# Altium schematic sheet USB-C Power.SchDoc("USB-C Power")
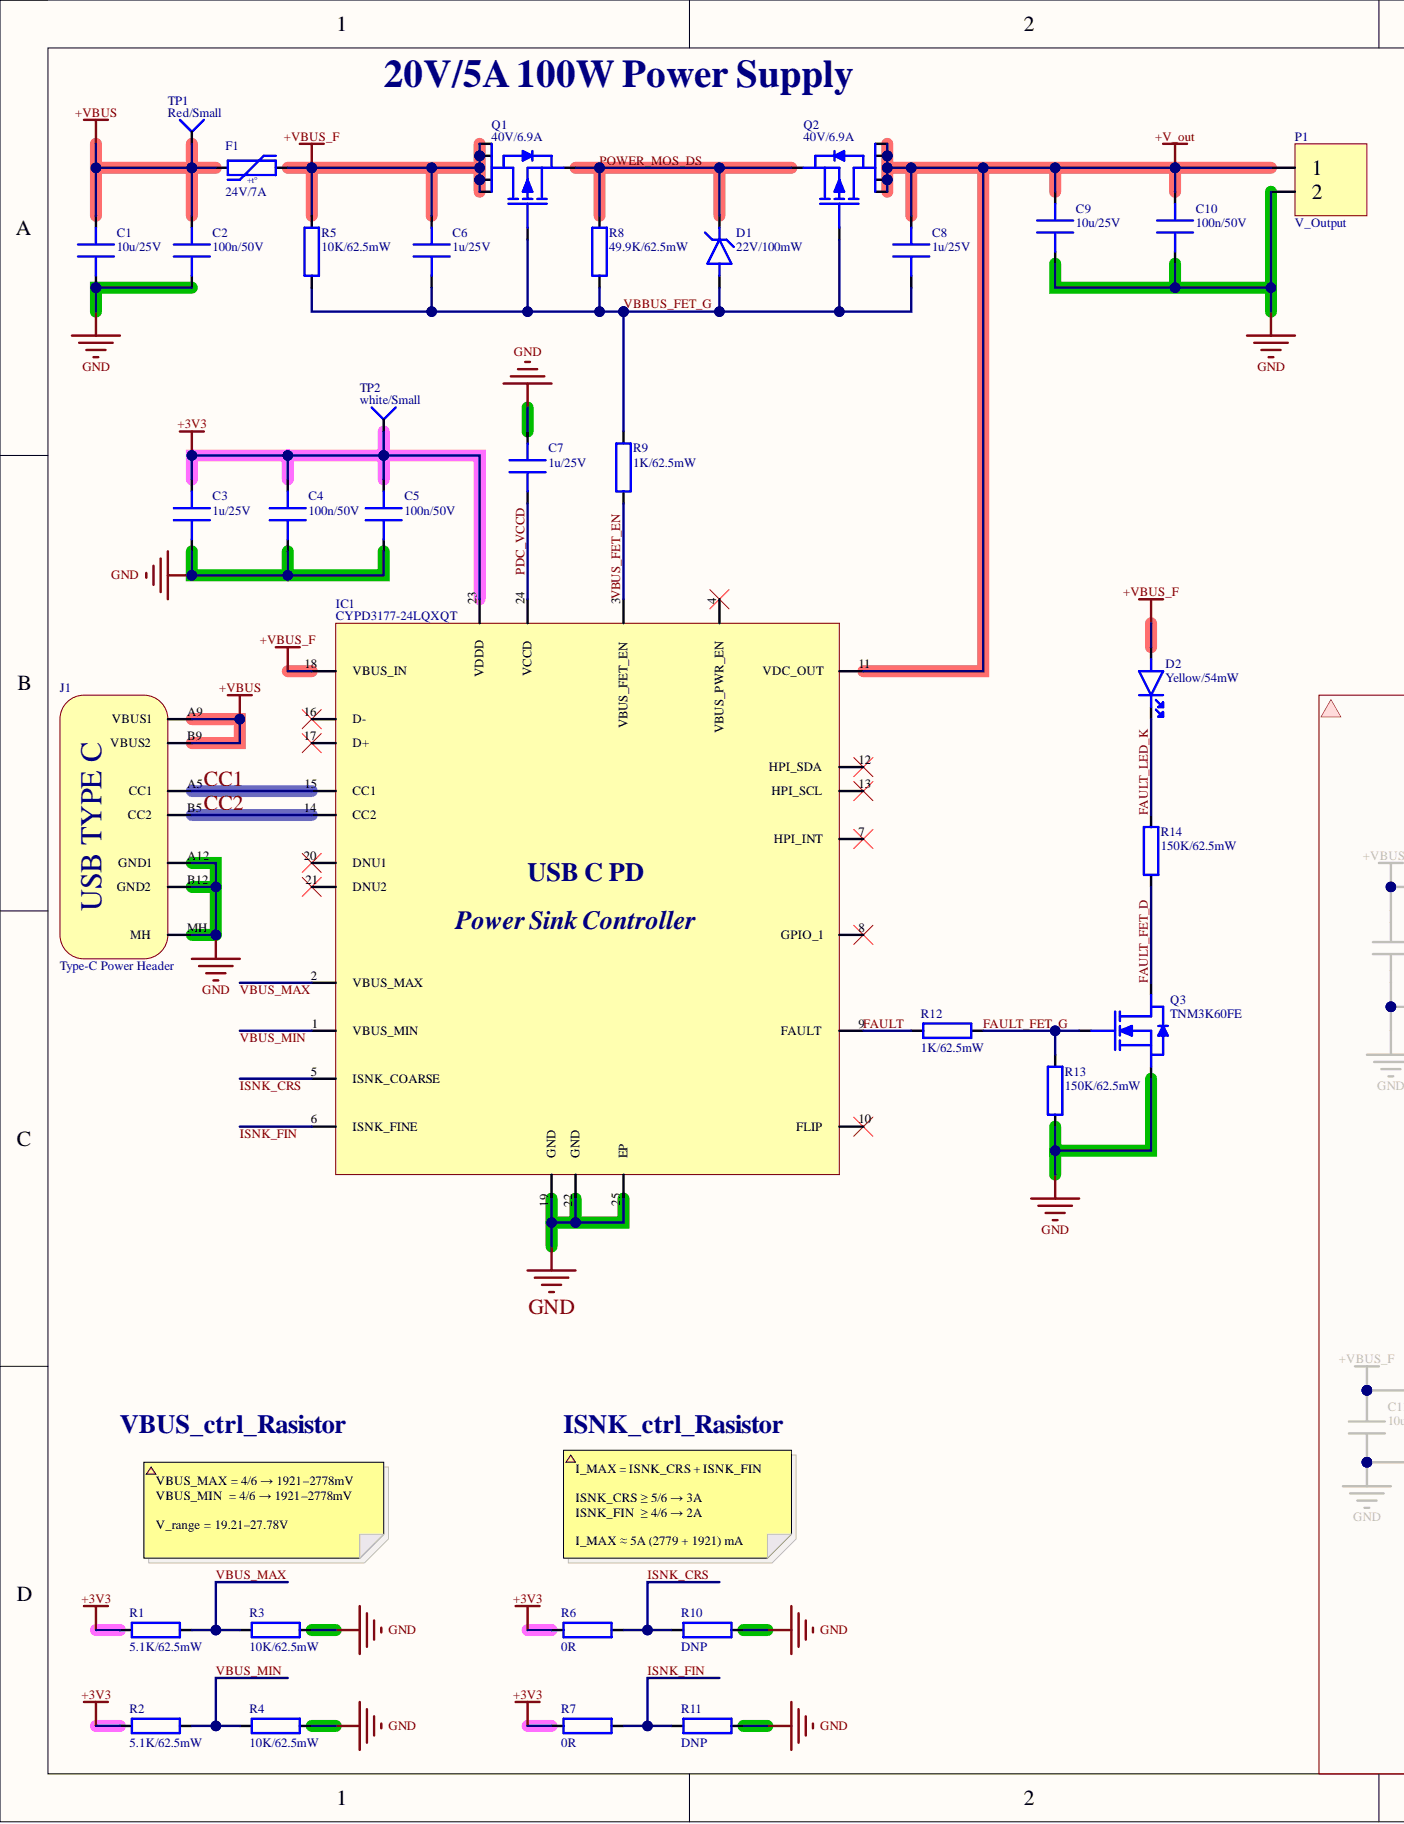
[diagram p0: USB-C Power.SchDoc, left]
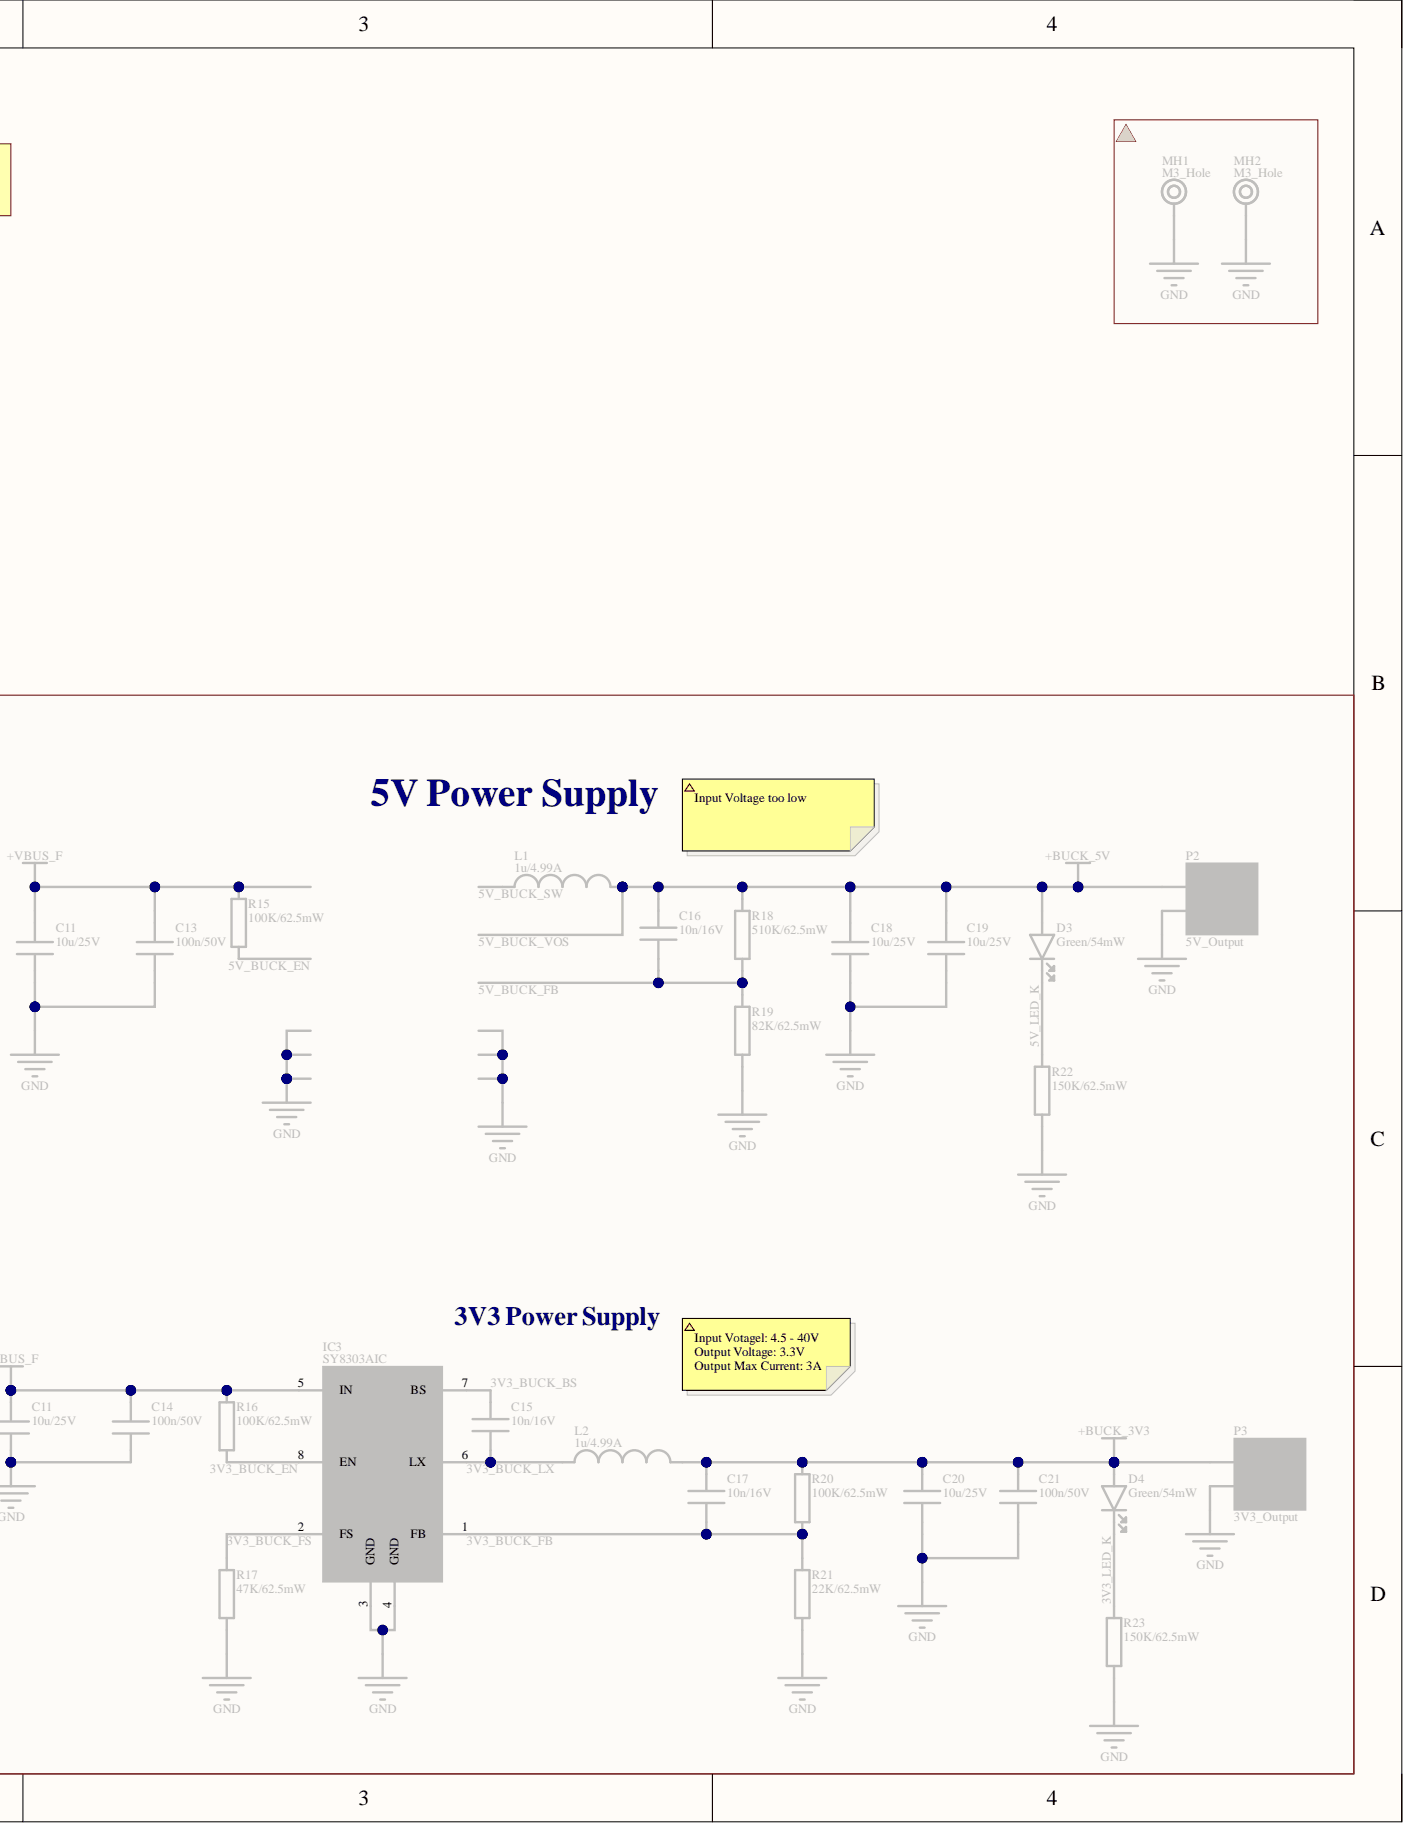
[diagram p1: USB-C Power.SchDoc, right]

## Parts
C1  10u/25V  [0805_Cap]
C2  100n/50V  [0402_CAP]
C3  1u/25V  [0805_Cap]
C4  100n/50V  [0402_CAP]
C5  100n/50V  [0402_CAP]
C6  1u/25V  [0805_Cap]
C7  1u/25V  [0805_Cap]
C8  1u/25V  [0805_Cap]
C9  10u/25V  [0805_Cap]
C10  100n/50V  [0402_CAP]
D1  22V/100mW  [SOD-923-2]
D2  Yellow/54mW  [0603_LED]
F1  24V/7A  [2920_PTC]
IC1  CYPD3177-24LQXQT  [QFN-24]
J1  Type-C Power Header  [Type-C_6_Female]
P1  V_Output  [KF350-2P]
Q1  40V/6.9A  [TSOP-6]
Q2  40V/6.9A  [TSOP-6]
Q3  TNM3K60FE  [CST3-3]
R1  5.1K/62.5mW  [0402_Res]
R2  5.1K/62.5mW  [0402_Res]
R3  10K/62.5mW  [0402_Res]
R4  10K/62.5mW  [0402_Res]
R5  10K/62.5mW  [0402_Res]
R6  0R  [0402_Res]
R7  0R  [0402_Res]
R8  49.9K/62.5mW  [0402_Res]
R9  1K/62.5mW  [0402_Res]
R10  DNP  [0402_Res]
R11  DNP  [0402_Res]
R12  1K/62.5mW  [0402_Res]
R13  150K/62.5mW  [0402_Res]
R14  150K/62.5mW  [0402_Res]
TP1  Red/Small  [TestPoint_small]
TP2  white/Small  [TestPoint_small]

## Nets
+3V3: C3.1 C4.1 C5.1 IC1.23(VDDD) R1.1 R2.1 R6.1 R7.1 TP2.1
+V_out: C8.1 C9.1 C10.1 IC1.11(VDC_OUT) P1.1 Q2.1 Q2.2 Q2.5 Q2.6
+VBUS: C1.1 C2.1 F1.1 J1.A9(VBUS1) J1.B9(VBUS2) TP1.1
+VBUS_F: C6.1 D2.1 F1.2 IC1.18(VBUS_IN) Q1.1 Q1.2 Q1.5 Q1.6 R5.1
GND: C1.2 C2.2 C3.2 C4.2 C5.2 C7.1 C9.2 C10.2 IC1.19(GND) IC1.22(GND) IC1.25(EP) J1.A12(GND1) J1.B12(GND2) J1.MH P1.2 Q3.3 R3.2 R4.2 R10.2 R11.2 R13.2
CC1: IC1.15(CC1) J1.A5(CC1)
CC2: IC1.14(CC2) J1.B5(CC2)
FAULT: IC1.9(FAULT) R12.1
FAULT_FET_D: Q3.1 R14.1
FAULT_FET_G: Q3.2 R12.2 R13.1
FAULT_LED_K: D2.2 R14.2
ISNK_CRS: IC1.5(ISNK_COARSE) R6.2 R10.1
ISNK_FIN: IC1.6(ISNK_FINE) R7.2 R11.1
PDC_VCCD: C7.2 IC1.24(VCCD)
POWER_MOS_DS: D1.2 Q1.4 Q2.4 R8.2
VBBUS_FET_G: C6.2 C8.2 D1.1 Q1.3 Q2.3 R5.2 R8.1 R9.2
VBUS_FET_EN: IC1.3(VBUS_FET_EN) R9.1
VBUS_MAX: IC1.2(VBUS_MAX) R1.2 R3.1
VBUS_MIN: IC1.1(VBUS_MIN) R2.2 R4.1

Not connected: IC1 4(VBUS_PWR_EN) 7(HPI_INT) 8(GPIO_1) 10(FLIP) 12(HPI_SDA) 13(HPI_SCL) 16(D-) 17(D+) 20(DNU1) 21(DNU2)

## Library data of the parts
C1 C9 = Cap: 25V 10uF X5R - Multilayer Ceramic Capacitors MLCC - SMD/SMT ROHS; Mfr.: Murata Electronics; Mfr. #: GRM21BR61E106KA73K; Component Kind: Standard; Datasheet: https://jlcpcb.com/parts/2nd/Capacitors/Multilayer_Ceramic_Capacitors_MLCC_SMD_SMT_33; Distributor #: C3909351; Distributor_Link: https://jlcpcb.com/partdetail/4477883-GRM21BR61E106KA73K/C3909351; Library Name: General_Devices.SchLib
C2 C4 C5 C10 = Cap: 16V 100nF -20%~+80% 0402  Multilayer Ceramic Capacitors MLCC - SMD/SMT ROHS; Mfr.: FH (Guangdong Fenghua Advanced Tech); Mfr. #: 0402F104Z160NT; Component Kind: Standard; Datasheet: https://datasheet.lcsc.com/lcsc/2202131930_FH--Guangdong-Fenghua-Advanced-Tech-0402F104Z160NT_C2838746.pdf; Distributor #: C2838746; Distributor_Link: https://jlcpcb.com/partdetail/3013916-0402F104Z160NT/C2838746; Library Name: General_Devices.SchLib
C3 C6-C8 = Cap: 25V 1uF Y5V ±20% 0805  Multilayer Ceramic Capacitors MLCC - SMD/SMT ROHS; Mfr.: FH (Guangdong Fenghua Advanced Tech); Mfr. #: 0805F105M250NT; Component Kind: Standard; Datasheet: https://datasheet.lcsc.com/lcsc/1810311214_FH--Guangdong-Fenghua-Advanced-Tech-0805F105M250NT_C89378.pdf; Distributor #: C89378; Distributor_Link: https://jlcpcb.com/partdetail/90558-0805F105M250NT/C89378; Library Name: General_Devices.SchLib
D1 = Diode_Zener: Zener Diodes CDZV22B is the 100mW type compact (1006 size) diode for voltage regulation.; Mfr.: ROHM Semiconductor; Mfr. #: CDZVT2R22B; Component Kind: Standard; Datasheet: https://www.rohm.com/datasheet?p=CDZV22B&dist=Mouser&media=referral&source=mouser.com&campaign=Mouser; Distributor #: 755-CDZVT2R22B; Distributor_Link: https://www.mouser.tw/ProductDetail/ROHM-Semiconductor/CDZVT2R22B?qs=4v%252BiZTmLVHG6BDwFzUsoYg%3D%3D; Library Name: General_Devices.SchLib
D2 = LED: 590nm~590nm yellow 54mW 0603  Light Emitting Diodes (LED) ROHS; Mfr.: ROHM Semicon; Mfr. #: SML-D12Y8WT86R; Component Kind: Standard; Datasheet: https://datasheet.lcsc.com/lcsc/2006151335_ROHM-Semicon-SML-D12Y8WT86R_C600870.pdf; Distributor #: C600870; Distributor_Link: https://jlcpcb.com/partdetail/RohmSemicon-SMLD12Y8WT86R/C600870; Library Name: General_Devices.SchLib
F1 = PTC: Resettable Fuses - PPTC PTC SMD RESETTABLE FUSE; Mfr.: Littelfuse; Mfr. #: 2920L700/24SLER; Component Kind: Standard; Datasheet: https://www.mouser.tw/datasheet/2/240/littelfuse_ptc_low_rho_datasheet_pdf-2956203.pdf; Distributor #: 576-2920L700/24SLER; Distributor_Link: https://www.mouser.tw/ProductDetail/Littelfuse/2920L700-24SLER?qs=7MVldsJ5UazQEVth1QGslQ%3D%3D; Library Name: General_Devices.SchLib
IC1 = CYPD3177-24LQXQ: USB Interface IC USB Type-C port controller CYPD3177; Mfr.: Infineon Technologies; Component Kind: Standard; Datasheet: https://www.mouser.tw/datasheet/2/196/Infineon_EZ_PD_BCR_Datasheet_USB_Type_C_Port_Contr-3161917.pdf; Distributor #: 727-CYPD3177-24LQXQT; Distributor_Link: https://www.mouser.tw/ProductDetail/727-CYPD3177-24LQXQT; Library Name: Power.SchLib; Mfr. #: CYPD3177-24LQXQT
J1 = Type-C_6_Female: 5A 1 Surface Mount 6 Female -25℃~+85℃ Type-C SMD USB Connectors ROHS; Mfr.: DEALON; MFR. #: USB-TYPE-C-010; Component Kind: Standard; Datasheet: https://datasheet.lcsc.com/lcsc/2201121330_DEALON-USB-TYPE-C-010_C2927030.pdf; Distributor #: C2927030; Distributor_Link: https://jlcpcb.com/partdetail/Dealon-USB_TYPE_C010/C2927030; Library Name: General_Connector.SchLib
P1 = Header_2x1: 10A -40℃~+105℃ 300V Green 18~24 Straight pin 3.5mm 0.75 1 2 Plugin,P=3.5mm  Screw terminal ROHS; Mfr.: Cixi Kefa Elec; Mfr. #: KF350-3.5-2P; Component Kind: Standard; Datasheet: https://datasheet.lcsc.com/lcsc/2303171000_Cixi-Kefa-Elec-KF350-3-5-2P_C474892.pdf; Distributor #: C474892; Distributor_Link: https://jlcpcb.com/partdetail/Cixi_KefaElec-KF350_3_52P/C474892; Library Name: General_Connector.SchLib
Q1 Q2 = MOSFET_P_1: MOSFET P Ch -40Vds 20Vgs AEC-Q101 Qualified; Mfr.: Vishay Semiconductors; Mfr. #: SQ3419EV-T1_GE3; Component Kind: Standard; Datasheet: https://www.vishay.com/doc?68210; Distributor #: 78-SQ3419EV-T1_GE3; Distributor_Link: https://www.mouser.tw/ProductDetail/Vishay-Semiconductors/SQ3419EV-T1_GE3?qs=Vcr9%2FL0R50hjQdrP75bU0Q%3D%3D&gclid=CjwKCAjwg-GjBhBnEiwAMUvNW2_QHlpdOmMyQk5t1a0eb3FLtCoKgaDvyU_lKGTsS4lC8mEsJurJAhoCUjcQAvD_BwE; Library Name: General_Devices.SchLib
Q3 = MOSFET_N: 60V 0.3A 2Ω@10V SOT-523  MOSFETs ROHS; Mfr.: Toshiba; Mfr. #: SSM3K72KCT,L3F; Component Kind: Standard; Datasheet: https://www.mouser.tw/datasheet/2/408/SSM3K72KCT_datasheet_en_20201124-1099204.pdf; Distributor #: 757-SSM3K72KCTL3F; Distributor_Link: https://www.mouser.tw/ProductDetail/757-SSM3K72KCTL3F; Library Name: General_Devices.SchLib
R1 R2 = Res: 62.5mW ±1% 5.1kΩ 0402  Chip Resistor - Surface Mount ROHS; Mfr.: Sunway; Mfr. #: SC0402F5101F2ANRH; Component Kind: Standard; Datasheet: https://datasheet.lcsc.com/lcsc/2207281800_Sunway-SC0402F5101F2ANRH_C3152095.pdf; Distributor #: C3152095; Distributor_Link: https://jlcpcb.com/partdetail/Sunway-SC0402F5101F2ANRH/C3152095; Library Name: General_Devices.SchLib
R3-R5 = Res: 62.5mW Thick Film Resistors ±100ppm/℃ ±5% 10kΩ 0402  Chip Resistor - Surface Mount ROHS; Mfr.: Viking Tech; Mfr. #: CR-02JA6---10K; Component Kind: Standard; Datasheet: https://datasheet.lcsc.com/lcsc/2111241930_Viking-Tech-CR-02JA6---10K_C2924170.pdf; Distributor #: C2924170; Distributor_Link: https://jlcpcb.com/partdetail/VikingTech-CR_02JA6_10K/C2924170; Library Name: General_Devices.SchLib
R6 R7 = Res: 62.5mW ±300ppm/℃ ±5% 0Ω 0402 Chip Resistor - Surface Mount ROHS; Mfr.: Sunway; Mfr. #: SC0402J0000I2ANRH; Component Kind: Standard; Datasheet: https://datasheet.lcsc.com/lcsc/2207281800_Sunway-SC0402J0000I2ANRH_C3152098.pdf; Distributor #: C3152098; Distributor_Link: https://jlcpcb.com/partdetail/Sunway-SC0402J0000I2ANRH/C3152098; Library Name: General_Devices.SchLib
R8 = Res: 62.5mW Thick Film Resistors ±1% 49.9kΩ 0402  Chip Resistor - Surface Mount ROHS; Mfr.: LIZ Elec; Mfr. #: CR0402FF4992G; Component Kind: Standard; Datasheet: https://datasheet.lcsc.com/lcsc/1810170232_LIZ-Elec-CR0402FF4992G_C100457.pdf; Distributor #: C100457; Distributor_Link: https://jlcpcb.com/partdetail/LizElec-CR0402FF4992G/C100457; Library Name: General_Devices.SchLib
R9 R12 = Res: 63mW ±5% 1kΩ 0402  Chip Resistor - Surface Mount ROHS; Mfr.: Ever Ohms Tech; Mfr. #: CR0402J1K00Q10Z; Component Kind: Standard; Datasheet: https://datasheet.lcsc.com/lcsc/2010191904_Ever-Ohms-Tech-CR0402J1K00Q10Z_C881213.pdf; Distributor #: C881213; Distributor_Link: https://jlcpcb.com/partdetail/Ever_OhmsTech-CR0402J1K00Q10Z/C881213; Library Name: General_Devices.SchLib
R10 R11 = Res: Component Kind: Standard; Datasheet: N/A; Description: DNP; Distributor #: N/A; Distributor_Link: N/A; Library Name: General_Devices.SchLib; Mfr.: N/A; Mfr. #: N/A
R13 R14 = Res: 62.5mW Thick Film Resistors ±100ppm/℃ ±5% 150kΩ 0402 Chip Resistor - Surface Mount ROHS; Mfr.: Viking Tech; Mfr. #: ARG03FTC1503; Component Kind: Standard; Datasheet: https://datasheet.lcsc.com/lcsc/1912111437_Viking-Tech-CR-02JL6--150K_C409708.pdf; Distributor #: C409708; Distributor_Link: https://jlcpcb.com/partdetail/VikingTech-ARG03FTC1503/C409708; Library Name: General_Devices.SchLib
TP1 TP2 = Test_Point: General samll test point; Component Kind: Standard; Library Name: General_Connector.SchLib
